# Altium schematic sheet main.SchDoc
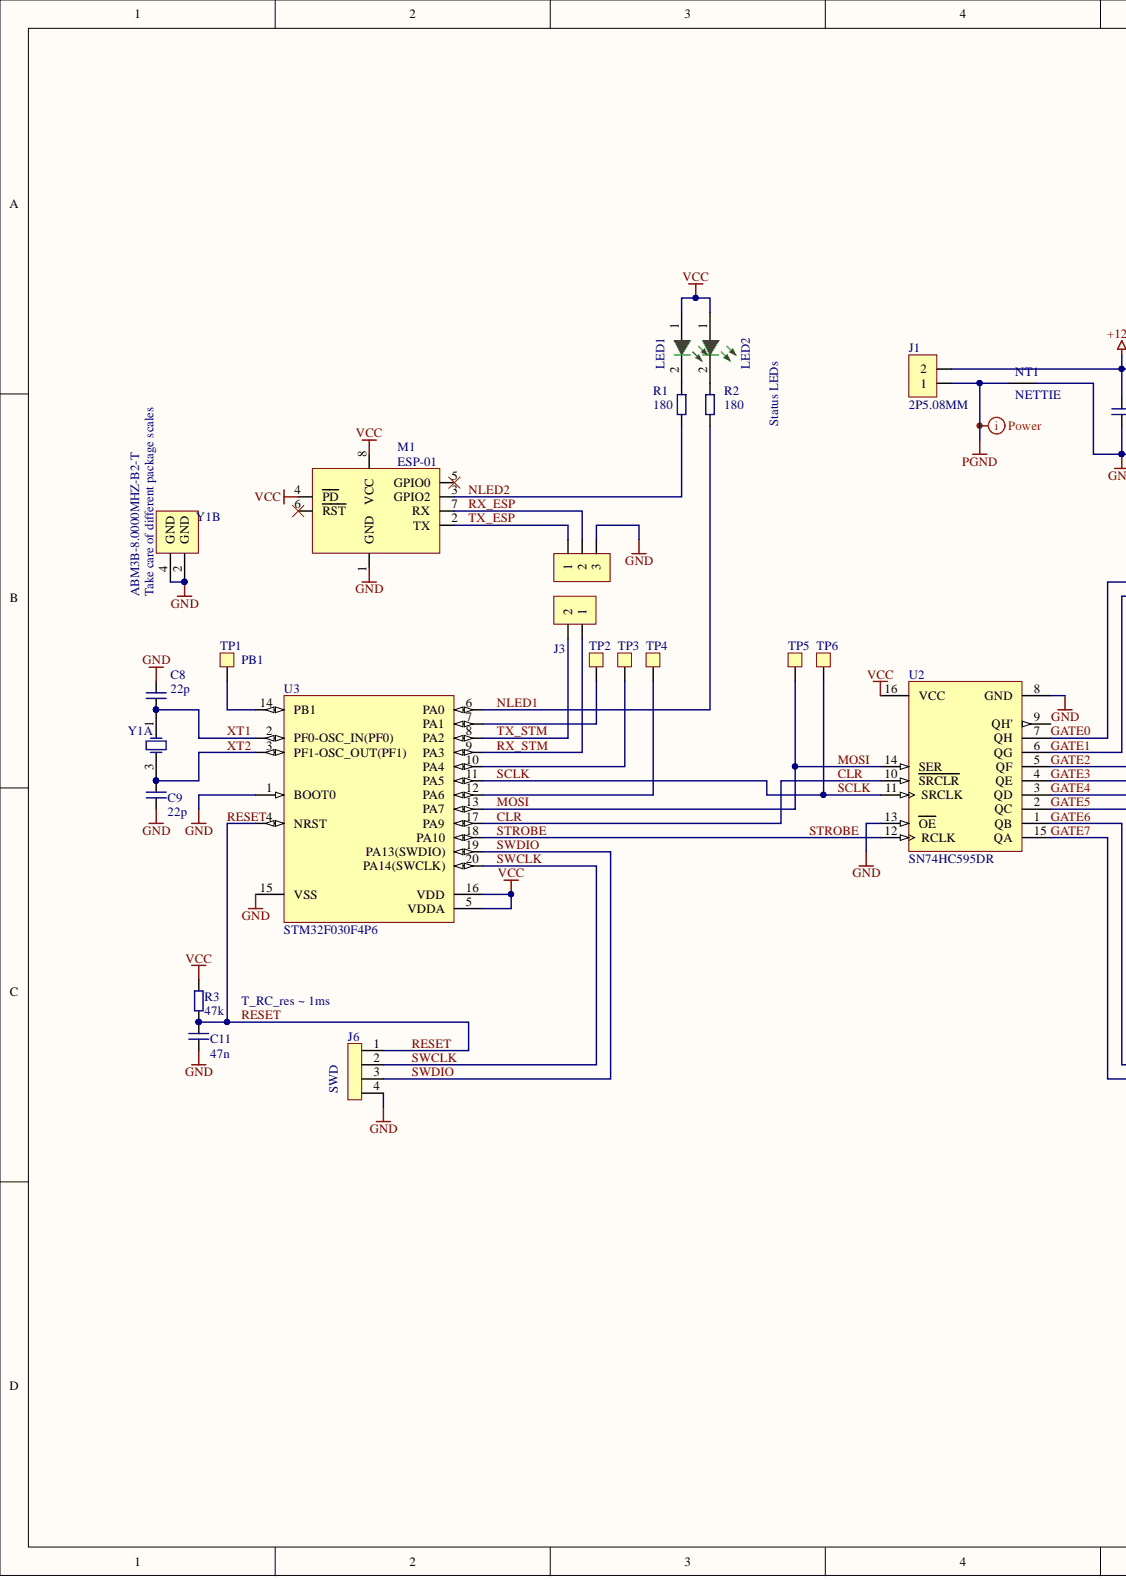
[diagram p0: main.SchDoc, left]
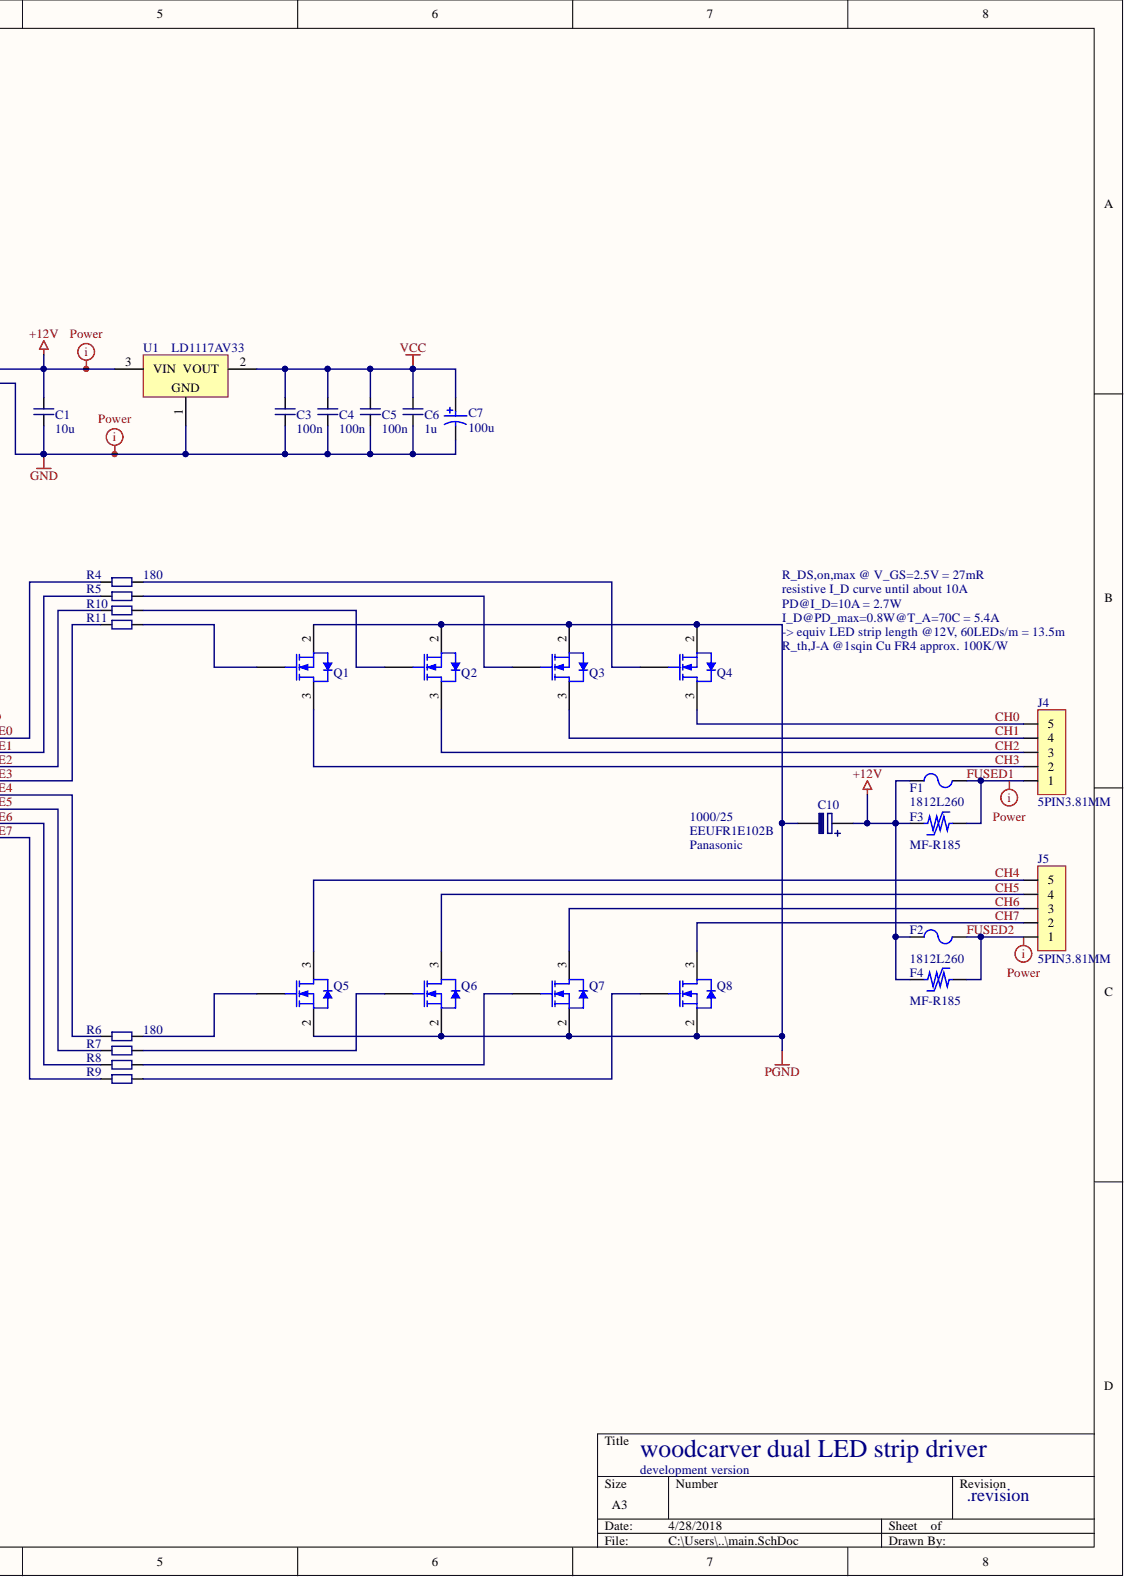
[diagram p1: main.SchDoc, right]

## Parts
C1  10u  [6-0805_M]
C3  100n  [J1-0603]
C4  100n  [J1-0603]
C5  100n  [J1-0603]
C6  1u  [6-0805_M]
C7  100u  [FHTE-8-AA07-2_N]
C8  22p  [J1-0603]
C9  22p  [J1-0603]
C10  1000/25  Mfg Part No: EEUFR1E102B  [ELKO_10MM_RM5_SIDE_25MM]
C11  47n  [J1-0603]
F1  1812L260  [LFUS-FUSE1812-71_V]
F2  1812L260  [LFUS-FUSE1812-71_V]
F3  MF-R185  [BOUR-MF-R185]
F4  MF-R185  [BOUR-MF-R185]
J1  2P5.08MM  [HEADER_2PIN_RM5.08MM_PLUGGABLE]
J2  PINHD_1x3_2.54MM  [PINHD_3X1_2.54MM]  (value not drawn)
J3  PINHD_1x2_2.54MM  [PINHD_2X1_2.54MM]  (value not drawn)
J4  5PIN3.81MM  [HEADER_5PIN_RM3.81MM_PLUGGABLE]
J5  5PIN3.81MM  [HEADER_5PIN_RM3.81MM_PLUGGABLE]
J6  SWD  [PINHD_4X1_2.54MM]
LED1  KP-1608MGC  [KING-0603LED_GREEN_V]  (value not drawn)
LED2  KP-1608MGC  [KING-0603LED_GREEN_V]  (value not drawn)
M1  ESP-01  [ESP01]
NT1  NETTIE  [NETTIE]
Q1  IRLML6244TRPbF  [ONSC-SOT-23-3-318-08_V]  (value not drawn)
Q2  IRLML6244TRPbF  [ONSC-SOT-23-3-318-08_V]  (value not drawn)
Q3  IRLML6244TRPbF  [ONSC-SOT-23-3-318-08_V]  (value not drawn)
Q4  IRLML6244TRPbF  [ONSC-SOT-23-3-318-08_V]  (value not drawn)
Q5  IRLML6244TRPbF  [ONSC-SOT-23-3-318-08_V]  (value not drawn)
Q6  IRLML6244TRPbF  [ONSC-SOT-23-3-318-08_V]  (value not drawn)
Q7  IRLML6244TRPbF  [ONSC-SOT-23-3-318-08_V]  (value not drawn)
Q8  IRLML6244TRPbF  [ONSC-SOT-23-3-318-08_V]  (value not drawn)
R1  180  [J1-0603]
R2  180  [J1-0603]
R3  47k  [J1-0603]
R4  180  [J1-0603]
R5  180  [J1-0603]  (value not drawn)
R6  180  [J1-0603]
R7  180  [J1-0603]  (value not drawn)
R8  180  [J1-0603]  (value not drawn)
R9  180  [J1-0603]  (value not drawn)
R10  180  [J1-0603]  (value not drawn)
R11  180  [J1-0603]  (value not drawn)
TP1  PB1  [TESTPOINT_2mm]
TP2  PA1  [TESTPOINT_2mm]  (value not drawn)
TP3  PA4  [TESTPOINT_2mm]  (value not drawn)
TP4  PA6  [TESTPOINT_2mm]  (value not drawn)
TP5  PA6  [TESTPOINT_2mm]  (value not drawn)
TP6  PA6  [TESTPOINT_2mm]  (value not drawn)
U1  LD1117AV33  [TO220]
U2  SN74HC595DR  [D0016A_M]
U3  STM32F030F4P6  [STM-TSSOP20_L]
Y1  ABM3B-8.0000MHZ-B2-T  Note: Take care of different package scales  [XTAL_MJ_5x3.2]  (parts A,B)

## Nets
+12V: C1.1 C10.1 F1.1 F2.1 F3.1 F4.1 J1.2 U1.3
GND: C1.2 C3.2 C4.2 C5.2 C6.2 C7.2 C8.1 C9.2 C11.2 J2.3 J6.4 M1.1(GND) NT1.2 U1.1 U2.8(GND) U2.13(OE) U3.1(BOOT0) U3.15(VSS) Y1.2(GND) Y1.4(GND)
PGND: C10.2 J1.1 NT1.1 Q1.2 Q2.2 Q3.2 Q4.2 Q5.2 Q6.2 Q7.2 Q8.2
VCC: C3.1 C4.1 C5.1 C6.1 C7.1 LED1.1 LED2.1 M1.4(PD) M1.8(VCC) R3.2 U1.2 U2.16(VCC) U3.5(VDDA) U3.16(VDD)
CH0: J4.5 Q4.3
CH1: J4.4 Q3.3
CH2: J4.3 Q2.3
CH3: J4.2 Q1.3
CH4: J5.5 Q5.3
CH5: J5.4 Q6.3
CH6: J5.3 Q7.3
CH7: J5.2 Q8.3
CLR: U2.10(SRCLR) U3.17(PA9)
FUSED1: F1.2 F3.2 J4.1
FUSED2: F2.2 F4.2 J5.1
GATE0: R4.2 U2.7(QH)
GATE1: R5.2 U2.6(QG)
GATE2: R10.2 U2.5(QF)
GATE3: R11.2 U2.4(QE)
GATE4: R6.2 U2.3(QD)
GATE5: R7.2 U2.2(QC)
GATE6: R8.2 U2.1(QB)
GATE7: R9.2 U2.15(QA)
MOSI: TP5.1 U2.14(SER) U3.13(PA7)
NLED1: R2.1 U3.6(PA0)
NLED2: M1.3(GPIO2) R1.1
RESET: C11.1 J6.1 R3.1 U3.4(NRST)
RX_ESP: J2.2 M1.7(RX)
RX_STM: J3.1 U3.9(PA3)
SCLK: TP6.1 U2.11(SRCLK) U3.11(PA5)
STROBE: U2.12(RCLK) U3.18(PA10)
SWCLK: J6.2 U3.20(PA14(SWCLK))
SWDIO: J6.3 U3.19(PA13(SWDIO))
TX_ESP: J2.1 M1.2(TX)
TX_STM: J3.2 U3.8(PA2)
XT1: C8.2 U3.2(PF0-OSC_IN(PF0)) Y1.1
XT2: C9.1 U3.3(PF1-OSC_OUT(PF1)) Y1.3
NetLED1_2: LED1.2 R1.2
NetLED2_2: LED2.2 R2.2
NetQ1_1: Q1.1 R11.1
NetQ2_1: Q2.1 R10.1
NetQ3_1: Q3.1 R5.1
NetQ4_1: Q4.1 R4.1
NetQ5_1: Q5.1 R6.1
NetQ6_1: Q6.1 R7.1
NetQ7_1: Q7.1 R8.1
NetQ8_1: Q8.1 R9.1
NetTP1_1: TP1.1 U3.14(PB1)
NetTP2_1: TP2.1 U3.7(PA1)
NetTP3_1: TP3.1 U3.10(PA4)
NetTP4_1: TP4.1 U3.12(PA6)

Not connected: M1 5(GPIO0) 6(RST); U2 9(QH')

## Library data of the parts
C1 C3-C6 C8 C9 C11 = C: Capacitor; Component Kind: Standard; Library Name: components.SchLib
C7 = CMP-0909-00002-1: Aluminum Electrolytic Capacitor, 470 uF +/- 5%, 16 V, -40 to 105 degC, 2 Pin THD, Dia 6.3 mm, Body Length 7 mm, Tape and Reel; Manufacturer: Fenghuang Technology; PartNumber: 8471LCJAA07WPN081T; Nominal Capacitance: 470 uF; Seeve Color: Balck; Tolerance: +/- 5%; Voltage: 16 V; Component Kind: Standard; ComponentLink1Description: Manufacturer URL; ComponentLink1URL: http://www.fenghua-advanced.com/; ComponentLink2Description: Datasheet; ComponentLink2URL: http://218.15.223.226:8089/BusinessProductPdf/ss_20130228152314.pdf; DatasheetVersion: Feb-13; Library Name: Altium Content Vault; Mounting Technology: Through Hole; Operating Temperature: -40 to 105 degC; PackageDescription: 2-Pin Through Hole Cap, Dia 6.3 mm, Body Length 7 mm; PackageReference: 8-AA07-2; Packing: Tape and Reel
C10 = ELKO: Mfg: Panasonic; Component Kind: Standard; Library Name: components.SchLib
F1 F2 = CMP-0257-00001-1: Resettable PTC, 1812, 1.5 A Ihold, 3.0 A Itrip; PartNumber: 1812L150ZR; Ihold: 1.5 A; Imax: 100 A; Itrip: 3 A; Vmax: 8 Vdc; Component Kind: Standard; ComponentLink1Description: Manufacturer URL; ComponentLink1URL: http://www.littelfuse.com/; ComponentLink2Description: Datasheet; ComponentLink2URL: http://www.littelfuse.com/products/resettable-ptcs/surface-mount/1812l/~/media/Electronics_Technical/Datasheets/Resettable_PTCs/Littelfuse_PTC_1812L_Datasheet.pdf; DatasheetVersion: 6/2012; Library Name: Altium Content Vault; PackageDescription: 2-Pin SMT Fuse, 4.55 x 3.24 mm Body; PackageReference: FUSE1812-71; PackageVersion: 6/2012
F3 F4 = CMP-2000-06695-1: PTC Resettable Fuse, 30 V, 40 A, -40 to 85 degC, 2-Pin THD, RoHS, Bulk; Manufacturer: Bourns; Agency Approvals: CSA/TUV/UL; COO: CN; Hold Current: 1.85A; Lead Diameter: 0.51mm; Maximum Current Rating: 40A; Maximum Initial Resistance: 0.07Ohm; Maximum Post Trip Resistance: 0.11Ohm; Maximum Trip Time: 8.7@9.25As; Maximum Voltage Rating: 30V; Minimum Initial Resistance: 0.04Ohm; Trip Current: 3.7A; Typical Power Dissipation: 1W; Wire Form: InsideCrimpStandoff; Applications: AutomotiveApplications,ComputersandPeripherals,GeneralElectronics; Component Kind: Standard; Datasheet URL: http://www.bourns.com/docs/Product-Datasheets/mfr.pdf; Datasheet Version: 08/2015; Dimension: 3(Max)x12(Max)mm; ECCN: EAR99; Family: MF-R; HTS: 8536100040; Library Name: Altium Content Vault; Manufacturer URL: http://www.bourns.com/; Mounting: ThroughHole; Mounting Technology: ThroughHole; Number of Terminals: 2; Operating Temperature: -40to85C; Package/Case: N/A; Package Description: 2-Pin Through Hole Device, Body 12 x 3 mm; Package Reference: MF-R185; Packaging: Bulk; Part No: MF-R185; RoHS: Yes; Seated Plane Height: 18.4(Max)mm; Supplier: Bourns; Supplier Standard Pack: 500; Terminal Pitch: 5.1(Nom)mm
J1 = PHD2P5.08RM: Pluggable Header 2 Pin RM 5.08mm ~15A; Component Kind: Standard; Library Name: components.SchLib
J2 = PINHD1X3: Pin Header 1 row 3 pin RM 2.54mm; Component Kind: Standard; Library Name: components.SchLib
J3 = PINHD1X2: Pin Header 1 row 2 pin RM 2.54mm; Component Kind: Standard; Library Name: components.SchLib
J4 J5 = PHD5P3.81RM: Pluggable Header 5 Pin RM 3.81mm ~8A; Component Kind: Standard; Library Name: components.SchLib
J6 = PH_4x1: Pin Header 4x1 pin; Component Kind: Standard; Library Name: components.SchLib
LED1 LED2 = CMP-0404-00001-1: LED, SMT, 0603(1608), 1.1mm thickness, Green; Manufacturer: Kingbright; Forward Current: 30 mA; Packing Type: 2000 pcs / reel; Power Dissipation: 75 mW; Reverse Voltage: 5 V; Component Kind: Standard; ComponentLink1Description: Manufacturer URL; ComponentLink1URL: http://www.kingbright.com/; Library Name: components.SchLib; Mounting Technology: Surface Mount; PackageDescription: 2-Pin SMT LED, 1.6 x 0.8 mm Pitch; PackageReference: 0603LED_GREEN; PartNumber: KP-1608MGC; Storage Temperature: -40 to +85 degC
M1 = ESP01: ESP-01 ESP8266 Wifi Module; Component Kind: Standard; Library Name: components.SchLib
Q1-Q8 = CMP-1058-00794-1: Power MOSFET, 500 mA, 60 V, N-Channel, 3-Pin SOT-23, Pb-Free, Tape and Reel; Manufacturer: On Semiconductor; PartNumber: NVBF170LT1G; Channel Polarity: N Channel; Configuration: Single; ID Max (A): 0.5; PD Max (W): 0.225; V(BR)DSS Min (V): 60; VGS(th) Max (V): 3; VGS Max (V): 20; Component Kind: Standard; ComponentLink1Description: Manufacturer URL; ComponentLink1URL: http://www.onsemi.com/; ComponentLink2Description: Datasheet; ComponentLink2URL: http://www.onsemi.cn/pub_link/Collateral/MMBF170LT1-D.PDF; ComponentLink3Description: Package Specification; ComponentLink3URL: http://www.onsemi.cn/pub_link/Collateral/318-08.PDF; DatasheetVersion: Rev. 9; Library Name: Altium Content Vault; Mounting Technology: Surface Mount; PackageDescription: 3-Pin Small Outline Transistor, Body 2.92 x 1.3 mm, Pitch 0.95 mm; PackageReference: SOT-23-3-318-08; Package Type: SOT-23-3; PackageVersion: Rev. AP, 11/2009; Packing: Tape and Reel; RoHS: Pb-Free
R1-R11 = R: Resistor; Component Kind: Standard; Library Name: components.SchLib
TP1-TP6 = TESTPOINT: Component Kind: Standard; Description: Testpoint; Library Name: components.SchLib
U1 = CMP-0244-00460-1: 1A, Low Drop Positive Voltage Regulator, 3.3V, 3-Pin TO-220; Component Kind: Standard; ComponentLink1Description: Manufacturer URL; ComponentLink1URL: http://www.st.com; ComponentLink2Description: Datasheet; ComponentLink2URL: http://www.st.com/internet/com/TECHNICAL_RESOURCES/TECHNICAL_LITERATURE/DATASHEET/CD00002116.pdf; ComponentLink3Description: Package Specification; ComponentLink3URL: http://www.st.com/internet/com/TECHNICAL_RESOURCES/TECHNICAL_LITERATURE/PACKAGE_INFORMATION/CD00004649.pdf; DatasheetVersion: 2/12/2011; Library Name: Altium Content Vault; PackageDescription: TO, Thru-Hole, Vertical, Heatsink Mounted; 3 In-Line Leads; Pitch 2.54 mm; PackageReference: TO220; PackageVersion: ver3.0
U2 = CMP-0780-00037-3: 8-Bit Shift Registers With 3-State Output Registers, D0016A, LARGE T&R; Manufacturer: Texas Instruments; 3-State Output: Yes; Bits: 4; F @ Nom Voltage(Max)(Mhz): 28; ICC @ Nom Voltage(Max)(mA): 0.08; Input Type: CMOS; Output Drive (IOL/IOH)(Max)(mA): 7.8/-7.8; Rating: Military; Technology Family: HC; tpd @ Nom Voltage(Max)(ns): 34; VCC(Max)(V): 6; VCC(Min)(V): 2; Code_JEDEC: MS-012 variation AC; Component Kind: Standard; DatasheetVersion: SCLS041H; Generic Part Number: SN74HC595; Library Name: Altium Content Vault; Operating Temperature Range(C): -40 to 85; Output Type: CMOS; PackageReference: D0016A; PackageVersion: http://www.ti.com/lit/ml/mpds178g/mpds178g.pdf; Voltage(Nom)(V): 6
U3 = CMP-0304-00039-2: ARM Cortex-M0 32-bit MCU, 16 KB Flash, 4 KB Internal RAM, 15 I/Os, 20-pin TSSOP, -40 to 85 degC, Tube; FLASH Size (Prog) (kB): 16; I/Os (High Current): 15; Internal RAM Size (kB): 4; Operating Frequency(F) (Processor speed) (MHz): 48; Supply Voltage(Vcc) min (V): 2.4; Component Kind: Standard; ComponentLink1Description: Manufacturer URL; ComponentLink1URL: http://www.st.com/web/en/home.html; ComponentLink2Description: Datasheet; ComponentLink2URL: http://www.st.com/st-web-ui/static/active/en/resource/technical/document/datasheet/DM00088500.pdf; ComponentLink3Description: Package Specification; ComponentLink3URL: http://www.st.com/st-web-ui/static/active/en/resource/technical/document/datasheet/DM00065136.pdf; Core: ARM Cortex-M0; DatasheetVersion: Rev. 1; Library Name: Altium Content Vault; Mounting Technology: Surface Mount; PackageDescription: 20-Pin Thin Shrink Small Outline Package, 6.5 x 4.4 mm Body, 0.65 mm Pitch; PackageReference: TSSOP20; PackageVersion: Rev. 1, 11/2012; Packing: Tube; PartNumber: STM32F030F4P6
Y1 = ABRA-ABM3B-4: Crystal, SMD, 25.000000MHz Stab=50ppm 18.0pF; Manufacturer: Abracon; PartNumber: ABM3B-25.000MHZ-B2-T; Body Shape: Rectangular; Packing Type: Tape & Reel; Case Size: 5.0 x 3.2 mm; Component Kind: Standard; ComponentLink1Description: Manufacturer URL; ComponentLink1URL: http://www.abracon.com/; ComponentLink2Description: Datasheet; ComponentLink2URL: http://www.abracon.com/Resonators/abm3b.pdf; DatasheetVersion: Apr-13; Library Name: components.SchLib; Mounting Technology: Surface Mount; PackageDescription: 4-Pin SMT Crystal, 5.2 x 3.4 mm Body; PackageReference: ABM3B; Storage Temperature: -40 to 80 degC
NT1 = NETTIE: Component Kind: Standard; Library Name: components.SchLib
